# Altium schematic sheet MCU_IO.SchDoc(MCU_IO)
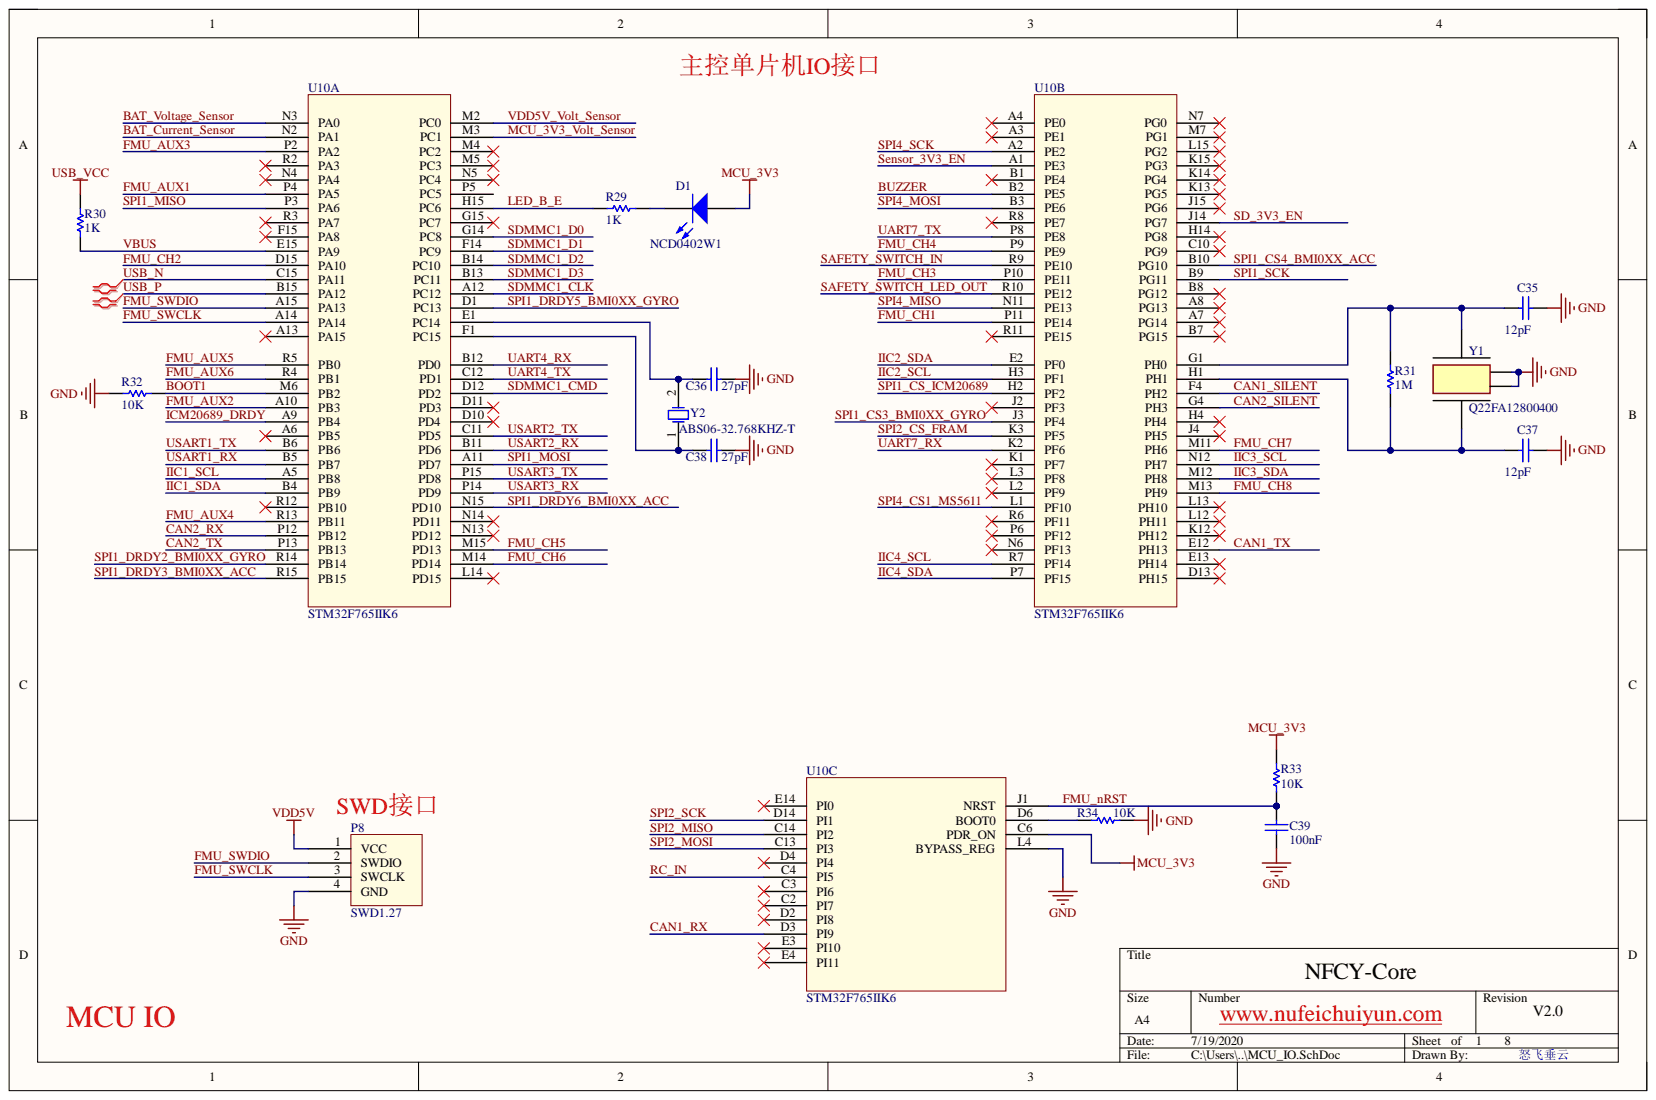
[diagram p0: MCU_IO.SchDoc]

## Parts
C35  value 12pF  [CAP0402]  (hidden comment: 0402CG120J500NT)
C36  value 27pF  [CAP0402]  (hidden comment: CC0402JRNPO9BN270)
C37  value 12pF  [CAP0402]  (hidden comment: 0402CG120J500NT)
C38  value 27pF  [CAP0402]  (hidden comment: CC0402JRNPO9BN270)
C39  value 100nF  [CAP0402]  (hidden comment: CL05B104KO5NNNC)
D1  NCD0402W1  [LED0402]
P8  SWD1.27  [HDR1p27X4]  (no BOM)
R29  value 1K  [RES0402]  (hidden comment: RC0402FR-071KL)
R30  value 1K  [RES0402]  (hidden comment: RC0402FR-071KL)
R31  value 1M  [RES0402]  (hidden comment: RC0402FR-071ML)
R32  value 10K  [RES0402]  (hidden comment: RC0402FR-0710KL)
R33  value 10K  [RES0402]  (hidden comment: RC0402FR-0710KL)
R34  value 10K  [RES0402]  (hidden comment: RC0402FR-0710KL)
U10  STM32F765IIK6  [UFBGA176+25]  (parts A,B,C)
Y1  Q22FA12800400  [OSC2016]
Y2  ABS06-32.768KHZ-T  [OSC2012]

## Nets
GND: C35.2 C36.2 C37.2 C38.2 C39.1 P8.4(GND) R32.2 R34.1 U10.L4(BYPASS_REG) Y1.2 Y1.4
MCU_3V3: D1.1 R33.2 U10.C6(PDR_ON)
USB_VCC: R30.1
VDD5V: P8.1(VCC)
BAT_Current_Sensor: U10.N2(PA1)
BAT_Voltage_Sensor: U10.N3(PA0)
BOOT1: R32.1 U10.M6(PB2)
BUZZER: U10.B2(PE5)
CAN1_RX: U10.D3(PI9)
CAN1_SILENT: U10.F4(PH2)
CAN1_TX: U10.E12(PH13)
CAN2_RX: U10.P12(PB12)
CAN2_SILENT: U10.G4(PH3)
CAN2_TX: U10.P13(PB13)
FMU_AUX1: U10.P4(PA5)
FMU_AUX2: U10.A10(PB3)
FMU_AUX3: U10.P2(PA2)
FMU_AUX4: U10.R13(PB11)
FMU_AUX5: U10.R5(PB0)
FMU_AUX6: U10.R4(PB1)
FMU_CH1: U10.P11(PE14)
FMU_CH2: U10.D15(PA10)
FMU_CH3: U10.P10(PE11)
FMU_CH4: U10.P9(PE9)
FMU_CH5: U10.M15(PD13)
FMU_CH6: U10.M14(PD14)
FMU_CH7: U10.M11(PH6)
FMU_CH8: U10.M13(PH9)
FMU_nRST: C39.2 R33.1 U10.J1(NRST)
FMU_SWCLK: P8.3(SWCLK) U10.A14(PA14)
FMU_SWDIO: P8.2(SWDIO) U10.A15(PA13)
ICM20689_DRDY: U10.A9(PB4)
IIC1_SCL: U10.A5(PB8)
IIC1_SDA: U10.B4(PB9)
IIC2_SCL: U10.H3(PF1)
IIC2_SDA: U10.E2(PF0)
IIC3_SCL: U10.N12(PH7)
IIC3_SDA: U10.M12(PH8)
IIC4_SCL: U10.R7(PF14)
IIC4_SDA: U10.P7(PF15)
LED_B_E: R29.2 U10.H15(PC6)
MCU_3V3_Volt_Sensor: U10.M3(PC1)
RC_IN: U10.C4(PI5)
SAFETY_SWITCH_IN: U10.R9(PE10)
SAFETY_SWITCH_LED_OUT: U10.R10(PE12)
SD_3V3_EN: U10.J14(PG7)
SDMMC1_CLK: U10.A12(PC12)
SDMMC1_CMD: U10.D12(PD2)
SDMMC1_D0: U10.G14(PC8)
SDMMC1_D1: U10.F14(PC9)
SDMMC1_D2: U10.B14(PC10)
SDMMC1_D3: U10.B13(PC11)
Sensor_3V3_EN: U10.A1(PE3)
SPI1_CS3_BMI0XX_GYRO: U10.J3(PF4)
SPI1_CS4_BMI0XX_ACC: U10.B10(PG10)
SPI1_CS_ICM20689: U10.H2(PF2)
SPI1_DRDY2_BMI0XX_GYRO: U10.R14(PB14)
SPI1_DRDY3_BMI0XX_ACC: U10.R15(PB15)
SPI1_DRDY5_BMI0XX_GYRO: U10.D1(PC13)
SPI1_DRDY6_BMI0XX_ACC: U10.N15(PD10)
SPI1_MISO: U10.P3(PA6)
SPI1_MOSI: U10.A11(PD7)
SPI1_SCK: U10.B9(PG11)
SPI2_CS_FRAM: U10.K3(PF5)
SPI2_MISO: U10.C14(PI2)
SPI2_MOSI: U10.C13(PI3)
SPI2_SCK: U10.D14(PI1)
SPI4_CS1_MS5611: U10.L1(PF10)
SPI4_MISO: U10.N11(PE13)
SPI4_MOSI: U10.B3(PE6)
SPI4_SCK: U10.A2(PE2)
UART4_RX: U10.B12(PD0)
UART4_TX: U10.C12(PD1)
UART7_RX: U10.K2(PF6)
UART7_TX: U10.P8(PE8)
USART1_RX: U10.B5(PB7)
USART1_TX: U10.B6(PB6)
USART2_RX: U10.B11(PD6)
USART2_TX: U10.C11(PD5)
USART3_RX: U10.P14(PD9)
USART3_TX: U10.P15(PD8)
USB_N: U10.C15(PA11)
USB_P: U10.B15(PA12)
VBUS: R30.2 U10.E15(PA9)
VDD5V_Volt_Sensor: U10.M2(PC0)
NetC35_1: C35.1 R31.1 U10.G1(PH0) Y1.3
NetC36_1: C36.1 U10.E1(PC14) Y2.2
NetC37_1: C37.1 R31.2 U10.H1(PH1) Y1.1
NetC38_1: C38.1 U10.F1(PC15) Y2.1
NetD1_2: D1.2 R29.1
NetR34_2: R34.2 U10.D6(BOOT0)

Not connected: U10 A3(PE1) A4(PE0) A6(PB5) A7(PG14) A8(PG13) A13(PA15) B1(PE4) B7(PG15) B8(PG12) C2(PI7) C3(PI6) C10(PG9) D2(PI8) D4(PI4) D10(PD4) D11(PD3) D13(PH15) E3(PI10) E4(PI11) E13(PH14) E14(PI0) F15(PA8) G15(PC7) H4(PH4) H14(PG8) J2(PF3) J4(PH5) J15(PG6) K1(PF7) K12(PH12) K13(PG5) K14(PG4) K15(PG3) L2(PF9) L3(PF8) L12(PH11) L13(PH10) L14(PD15) L15(PG2) M4(PC2) M5(PC3) M7(PG1) N4(PA4) N5(PC4) N6(PF13) N7(PG0) N13(PD12) N14(PD11) P5(PC5) P6(PF12) R2(PA3) R3(PA7) R6(PF11) R8(PE7) R11(PE15) R12(PB10)

## Library data of the parts
C35 = Cap: 贴片电容; manufacturer: FH(风华); Value: 12pF; Component Kind: Standard; LatestRevisionDate: 17-Jul-2002; LatestRevisionNote: Re-released for DXP Platform.; Library Name: Miscellaneous Devices.IntLib; PackageDescription: Radial Cap, Thru-Hole; 2 Leads; 0.3 in Pin Spacing; PackageReference: RAD-0.3; Published: 8-Jun-2000; Signal Integrity: Cap; Simulation: CAP; Publisher: Altium Limited
C36 C38 = Cap: 贴片电容; manufacturer: YAGEO(国巨); Component Kind: Standard; LatestRevisionDate: 17-Jul-2002; LatestRevisionNote: Re-released for DXP Platform.; Library Name: Miscellaneous Devices.IntLib; PackageDescription: Radial Cap, Thru-Hole; 2 Leads; 0.3 in Pin Spacing; PackageReference: RAD-0.3; Published: 8-Jun-2000; Signal Integrity: Cap; Simulation: CAP; Publisher: Altium Limited
C37 = Cap: 贴片电容; manufacturer: FH(风华); Component Kind: Standard; LatestRevisionDate: 17-Jul-2002; LatestRevisionNote: Re-released for DXP Platform.; Library Name: Miscellaneous Devices.IntLib; PackageDescription: Radial Cap, Thru-Hole; 2 Leads; 0.3 in Pin Spacing; PackageReference: RAD-0.3; Published: 8-Jun-2000; Signal Integrity: Cap; Simulation: CAP; Publisher: Altium Limited
C39 = Cap: 贴片电容; manufacturer: SAMSUNG(三星); Component Kind: Standard; LatestRevisionDate: 17-Jul-2002; LatestRevisionNote: Re-released for DXP Platform.; Library Name: Miscellaneous Devices.IntLib; PackageDescription: Radial Cap, Thru-Hole; 2 Leads; 0.3 in Pin Spacing; PackageReference: RAD-0.3; Published: 8-Jun-2000; Signal Integrity: Cap; Simulation: CAP; Publisher: Altium Limited
D1 = LED1: 白色LED; manufacturer: 国星光电; Component Kind: Standard; LatestRevisionDate: 08-Jul-2005; LatestRevisionNote: Mech Layer 1 removed.; Library Name: Miscellaneous Devices.IntLib; PackageDescription: LED; 2 Leads; PackageReference: LED-1; Published: 8-Jun-2000; Simulation: LED1; Publisher: Altium Limited
P8 = SWD1.27: STM32 SWD调试接口; Library Name: 排针.SchLib
R29-R34 = Res1: 贴片电阻; manufacturer: YAGEO(国巨); Component Kind: Standard; LatestRevisionDate: 17-Jul-2002; LatestRevisionNote: Re-released for DXP Platform.; Library Name: Miscellaneous Devices.IntLib; PackageDescription: Axial Device, Thru-Hole; 2 Leads; 0.3 in Pin Spacing; PackageReference: AXIAL-0.3; Published: 8-Jun-2000; Signal Integrity: Res; Simulation: RESISTOR; Publisher: Altium Limited
U10 = STM32F765IIK6: STM32F7; manufacturer: STMicroelectronics; Manufacturer_Part_Number: stm32f76xixk; C: Copyright (C) 2016 Accelerated Designs. All rights reserved; Component Kind: Standard; Library Name: STM32F765.IntLib; RefDes: RefDes; Type: Type; Vendor: STMicroelectronics; Copyright: Copyright (C) 2016 Accelerated Designs. All rights reserved
Y1 = Q22FA12800400: 16MHz 4pin 无源晶振; manufacturer: EPSON(爱普生); Component Kind: Standard; Library Name: OSC.SchLib
Y2 = XTAL: Crystal Oscillator; manufacturer: ABRACON; Component Kind: Standard; LatestRevisionDate: 17-Jul-2002; LatestRevisionNote: Re-released for DXP Platform.; Library Name: Miscellaneous Devices.IntLib; PackageDescription: Crystal, Thru-Hole; 2 Leads; Body 3.1 x 8.2 mm (Dia.xH); PackageReference: R38; Published: 8-Jun-2000; Simulation: XTAL; Publisher: Altium Limited
